# Altium schematic sheet Transmitter.SchDoc(Transmitter)
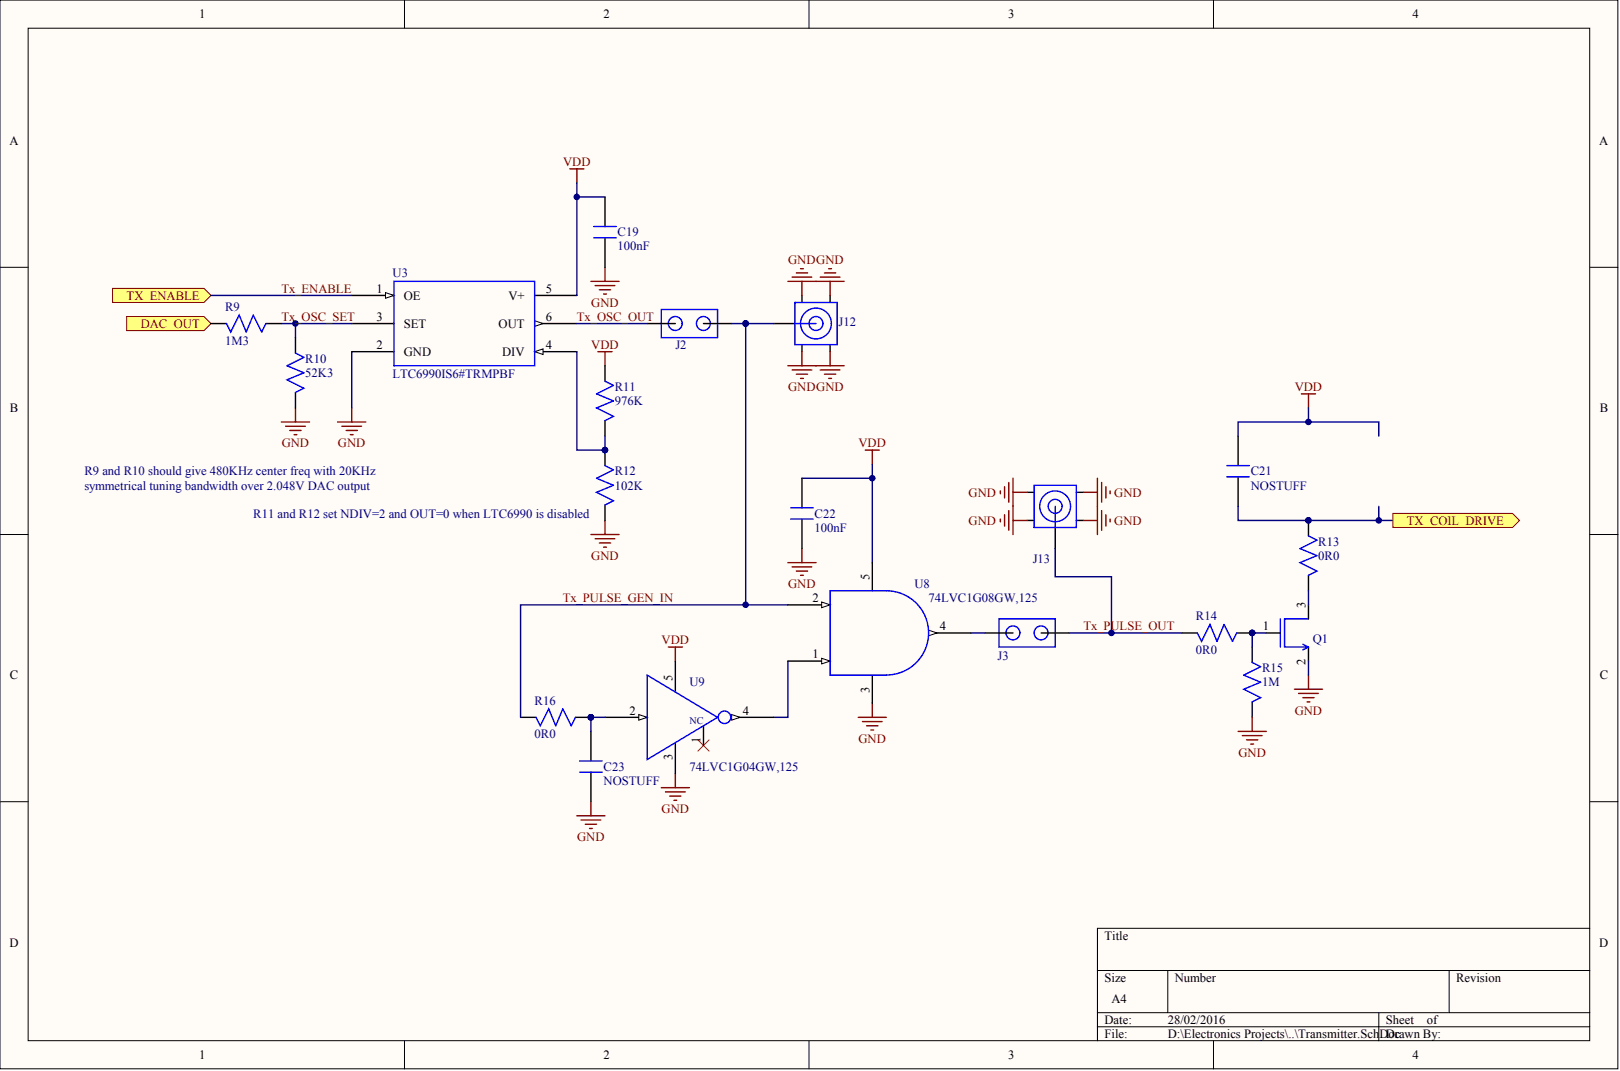
[diagram p0: Transmitter.SchDoc]

## Parts
C19  Capacitance: 100nF  (hidden comment: CAP_0402_100nF)
C21  CAP_0402_NOSTUFF  (value not drawn)
C22  Capacitance: 100nF  (hidden comment: CAP_0402_100nF)
C23  CAP_0402_NOSTUFF  (value not drawn)
J2  CONN_2PIN_HEADER  (value not drawn)
J3  CONN_2PIN_HEADER  (value not drawn)
J12  CONN_SMA_EDGEMOUNT  (value not drawn)
J13  CONN_SMA_EDGEMOUNT  (value not drawn)
Q1  2N7002P  (value not drawn)
R9  Resistance: 1M3  (hidden comment: RES_0402_1M3)
R10  Resistance: 52K3  (hidden comment: RES_0402_52K3)
R11  Resistance: 976K  (hidden comment: RES_0402_976K)
R12  Resistance: 102K  (hidden comment: RES_0402_102K)
R13  Resistance: 0R0  (hidden comment: RES_0402_0R0)
R14  Resistance: 0R0  (hidden comment: RES_0402_0R0)
R15  Resistance: 1M  (hidden comment: RES_0402_1M)
R16  Resistance: 0R0  (hidden comment: RES_0402_0R0)
U3  LTC6990  Part Number: LTC6990IS6#TRMPBF
U8  74LVC1G08  Part Number: 74LVC1G08GW,125
U9  74LVC1G04  Part Number: 74LVC1G04GW,125

## Ports
DAC_OUT, TX_COIL_DRIVE, TX_ENABLE

## Nets
GND: C19.1 C22.1 C23.1 J12.1 J12.2 J12.3 J12.4 J13.1 J13.2 J13.3 J13.4 Q1.2 R10.1 R12.1 R15.1 U3.2(GND) U8.3 U9.3
VDD: C19.2 C21.2 C22.2 R11.2 U3.5(V+) U8.5 U9.5
DAC_OUT: R9.1 ; port DAC_OUT
TX_COIL_DRIVE: C21.1 R13.2 ; port TX_COIL_DRIVE
TX_ENABLE: U3.1(OE) ; port TX_ENABLE
TX_OSC_OUT: J2.1 U3.6(OUT)
TX_OSC_SET: R9.2 R10.2 U3.3(SET)
TX_PULSE_GEN_IN: J2.2 J12.5 R16.1 U8.2
TX_PULSE_OUT: J3.2 J13.5 R14.1
NetC23_2: C23.2 R16.2 U9.2
NetJ3_1: J3.1 U8.4
NetQ1_1: Q1.1 R14.2 R15.2
NetQ1_3: Q1.3 R13.1
NetR11_1: R11.1 R12.2 U3.4(DIV)
NetU8_1: U8.1 U9.4

Not connected: U9 1

## Library data of the parts
C19 C22 = (no symbol name): Manufacturer: TDK; Part Number: C1005X5R1A104K050BA; Tolerance: 10%; Voltage: 10V
J2 J3 = (no symbol name): Manufacturer: 3M; Part Number: 961102-6404-AR
Q1 = (no symbol name): Manufacturer: NXP Semiconductors; Part Number: 2N7002P,215; Package: SOT-23-3
R9 = (no symbol name): Manufacturer: Vishay Dale; Part Number: CRCW04021M30FKED; Tolerance: 1%
R10 = (no symbol name): Manufacturer: Vishay Dale; Part Number: CRCW040252K3FKED; Tolerance: 1%
R11 = (no symbol name): Manufacturer: Vishay Dale; Part Number: CRCW0402976KFKED; Tolerance: 1%
R12 = (no symbol name): Manufacturer: Vishay Dale; Part Number: CRCW0402102KFKED; Tolerance: 1%
R15 = (no symbol name): Manufacturer: Stackpole Electronics; Part Number: RMCF0402FT1M00; Tolerance: 1%
U3 = (no symbol name): Manufacturer: Linear Technology; Package: TSOT-23
U8 U9 = (no symbol name): Manufacturer: NXP Semiconductors; Package: SOT-353
C21 C23 J12 J13 R13 R14 R16 = (no symbol name)
  C21 C23: NOSTUFF: NOSTUFF
